# Altium schematic sheet S3_Arduino.SchDoc(S3_Arduino)
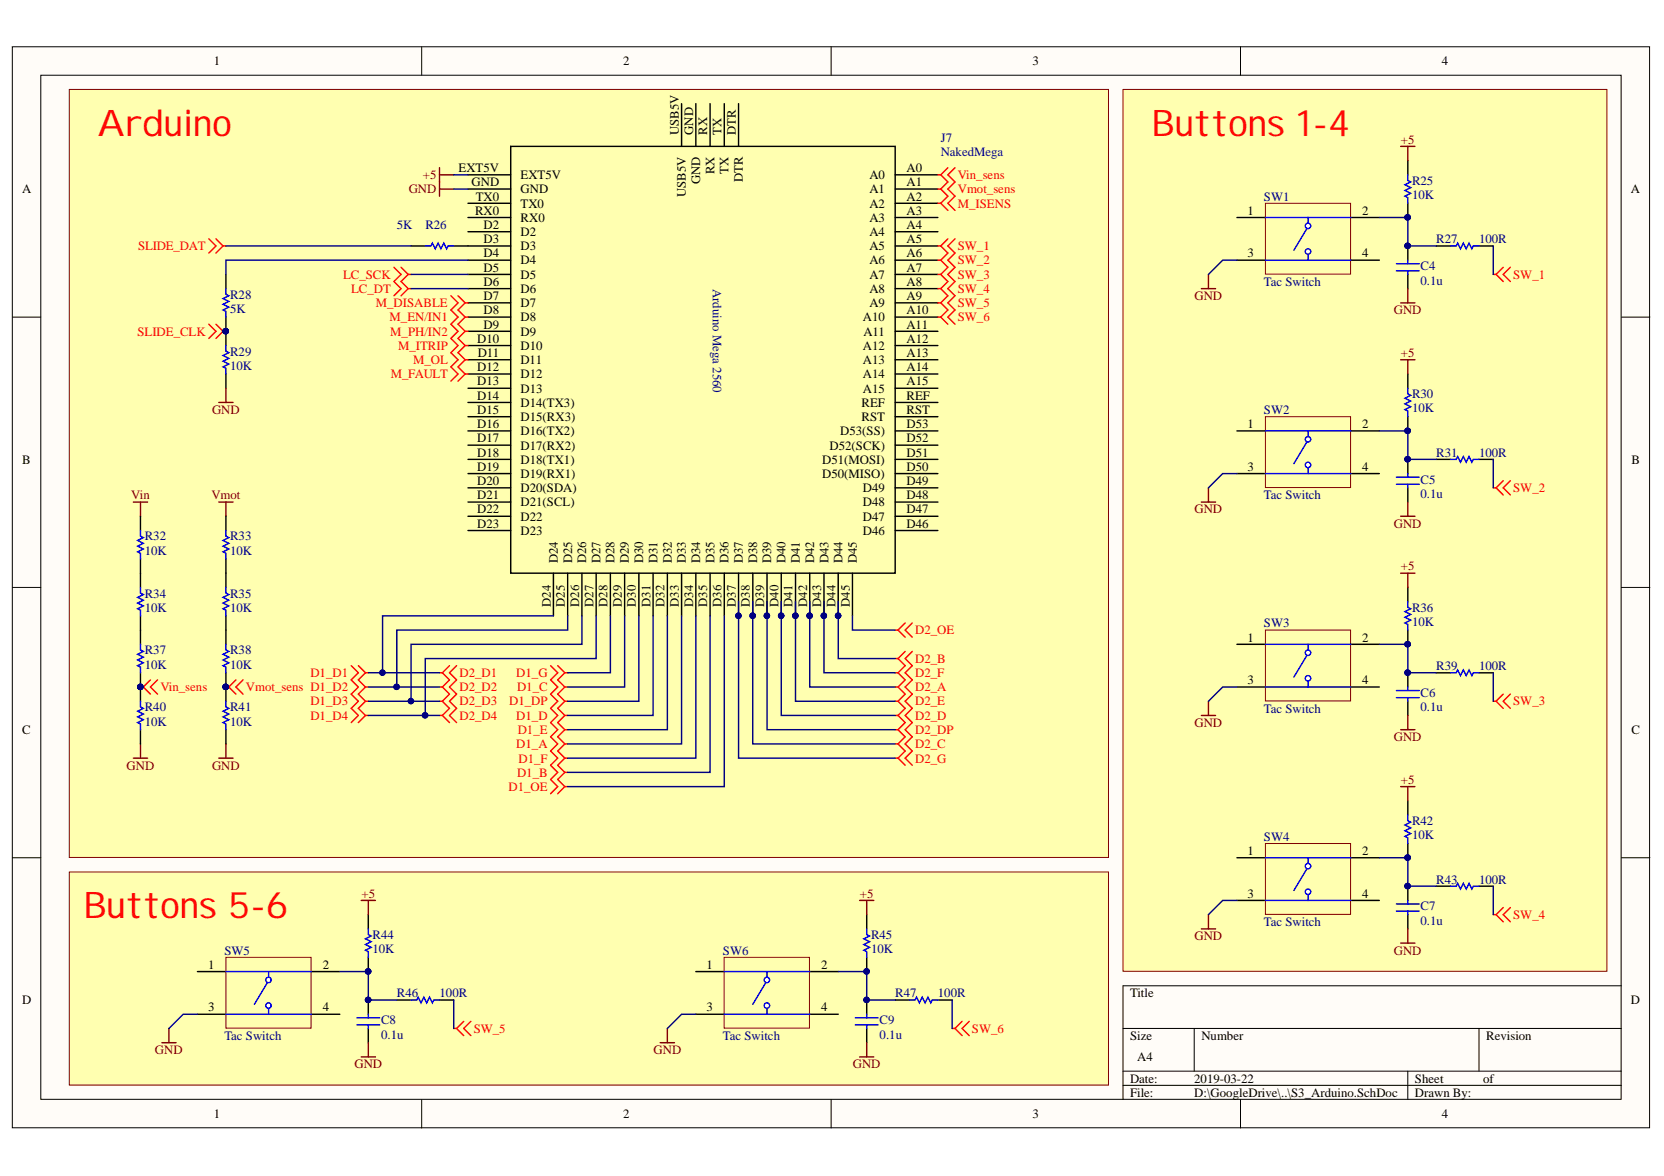
[diagram p0: S3_Arduino.SchDoc]

## Parts
C4  0.1u  [Ceramic Cap 5mm]
C5  0.1u  [Ceramic Cap 5mm]
C6  0.1u  [Ceramic Cap 5mm]
C7  0.1u  [Ceramic Cap 5mm]
C8  0.1u  [Ceramic Cap 5mm]
C9  0.1u  [Ceramic Cap 5mm]
J7  NakedMega  [Arduino Mega]
R25  value 10K  [AXIAL-0.3-3D]  (hidden comment: Res1)
R26  value 5K  [AXIAL-0.3-3D]  (hidden comment: Res1)
R27  value 100R  [AXIAL-0.3-3D]  (hidden comment: Res1)
R28  value 5K  [AXIAL-0.3-3D]  (hidden comment: Res1)
R29  value 10K  [AXIAL-0.3-3D]  (hidden comment: Res1)
R30  value 10K  [AXIAL-0.3-3D]  (hidden comment: Res1)
R31  value 100R  [AXIAL-0.3-3D]  (hidden comment: Res1)
R32  value 10K  [AXIAL-0.3-3D]  (hidden comment: Res1)
R33  value 10K  [AXIAL-0.3-3D]  (hidden comment: Res1)
R34  value 10K  [AXIAL-0.3-3D]  (hidden comment: Res1)
R35  value 10K  [AXIAL-0.3-3D]  (hidden comment: Res1)
R36  value 10K  [AXIAL-0.3-3D]  (hidden comment: Res1)
R37  value 10K  [AXIAL-0.3-3D]  (hidden comment: Res1)
R38  value 10K  [AXIAL-0.3-3D]  (hidden comment: Res1)
R39  value 100R  [AXIAL-0.3-3D]  (hidden comment: Res1)
R40  value 10K  [AXIAL-0.3-3D]  (hidden comment: Res1)
R41  value 10K  [AXIAL-0.3-3D]  (hidden comment: Res1)
R42  value 10K  [AXIAL-0.3-3D]  (hidden comment: Res1)
R43  value 100R  [AXIAL-0.3-3D]  (hidden comment: Res1)
R44  value 10K  [AXIAL-0.3-3D]  (hidden comment: Res1)
R45  value 10K  [AXIAL-0.3-3D]  (hidden comment: Res1)
R46  value 100R  [AXIAL-0.3-3D]  (hidden comment: Res1)
R47  value 100R  [AXIAL-0.3-3D]  (hidden comment: Res1)
SW1  Tac Switch  [TacSwitch]
SW2  Tac Switch  [TacSwitch]
SW3  Tac Switch  [TacSwitch]
SW4  Tac Switch  [TacSwitch]
SW5  Tac Switch  [TacSwitch]
SW6  Tac Switch  [TacSwitch]

## Nets
+5: J7.EXT5V R25.1 R30.1 R36.1 R42.1 R44.1 R45.1
D1_A: J7.D33
D1_B: J7.D35
D1_C: J7.D29
D1_D: J7.D31
D1_D1: J7.D24
D1_D2: J7.D25
D1_D3: J7.D26
D1_D4: J7.D27
D1_DP: J7.D30
D1_E: J7.D32
D1_F: J7.D34
D1_G: J7.D28
D1_OE: J7.D36
D2_A: J7.D42
D2_B: J7.D44
D2_C: J7.D38
D2_D: J7.D40
D2_DP: J7.D39
D2_E: J7.D41
D2_F: J7.D43
D2_G: J7.D37
D2_OE: J7.D45
GND: C4.2 C5.2 C6.2 C7.2 C8.2 C9.2 J7.GND R29.2 R40.2 R41.2 SW1.3 SW2.3 SW3.3 SW4.3 SW5.3 SW6.3
LC_DT: J7.D6
LC_SCK: J7.D5
M_DISABLE: J7.D7
M_EN/IN1: J7.D8
M_FAULT: J7.D12
M_ISENS: J7.A2
M_ITRIP: J7.D10
M_OL: J7.D11
M_PH/IN2: J7.D9
SLIDE_CLK: R28.2 R29.1
SLIDE_DAT: R26.1
SW_1: J7.A5 R27.2
SW_2: J7.A6 R31.2
SW_3: J7.A7 R39.2
SW_4: J7.A8 R43.2
SW_5: J7.A9 R46.2
SW_6: J7.A10 R47.2
Vin: R32.1
Vin_sens: J7.A0 R37.2 R40.1
Vmot: R33.1
Vmot_sens: J7.A1 R38.2 R41.1
NetC4_1: C4.1 R25.2 R27.1 SW1.2
NetC5_1: C5.1 R30.2 R31.1 SW2.2
NetC6_1: C6.1 R36.2 R39.1 SW3.2
NetC7_1: C7.1 R42.2 R43.1 SW4.2
NetC8_1: C8.1 R44.2 R46.1 SW5.2
NetC9_1: C9.1 R45.2 R47.1 SW6.2
NetJ7_D3: J7.D3 R26.2
NetJ7_D4: J7.D4 R28.1
NetR32_2: R32.2 R34.1
NetR33_2: R33.2 R35.1
NetR34_2: R34.2 R37.1
NetR35_2: R35.2 R38.1

Not connected: J7 A3 A4 A11 A12 A13 A14 A15 D2 D13 D14(D14(TX3)) D15(D15(RX3)) D16(D16(TX2)) D17(D17(RX2)) D18(D18(TX1)) D19(D19(RX1)) D20(D20(SDA)) D21(D21(SCL)) D22 D23 D46 D47 D48 D49 D50(D50(MISO)) D51(D51(MOSI)) D52(D52(SCK)) D53(D53(SS)) DTR REF RST RX RX0 TX TX0 USB5V; SW1 1 4; SW2 1 4; SW3 1 4; SW4 1 4; SW5 1 4; SW6 1 4

## Library data of the parts
C4-C9 = Cap: Library Name: Res and Cap.SchLib; Component Kind: Standard
R25-R47 = Res1: Resistor; Simulation: RESISTOR; Signal Integrity: Res; Published: 8-Jun-2000; PackageReference: AXIAL-0.3; PackageDescription: Axial Device, Thru-Hole; 2 Leads; 0.3 in Pin Spacing; Library Name: Miscellaneous Devices.IntLib; LatestRevisionNote: Re-released for DXP Platform.; LatestRevisionDate: 17-Jul-2002; Component Kind: Standard; Publisher: Altium Limited
J7 = NakedMega: Library Name: Custom.SchLib; Component Kind: Standard
SW1-SW6 = Tac Switch: Library Name: Custom.SchLib; Component Kind: Standard
